# Altium schematic sheet Sheet1.SchDoc
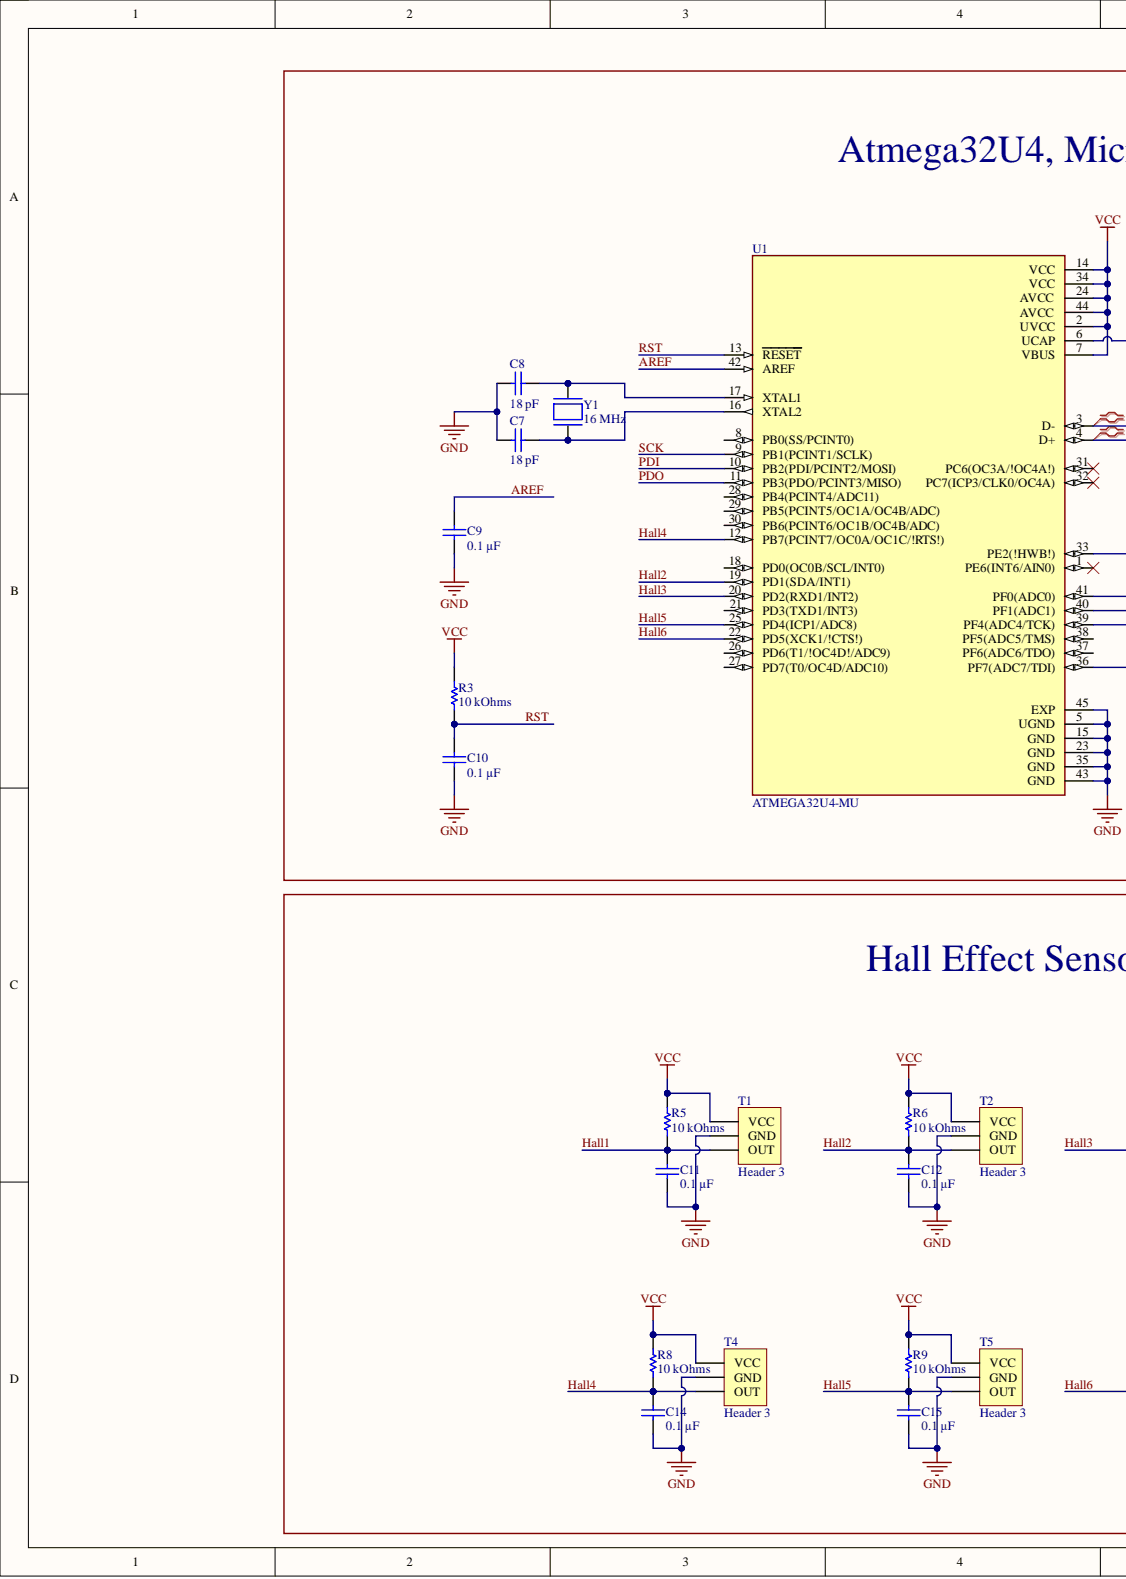
[diagram p0: Sheet1.SchDoc, left]
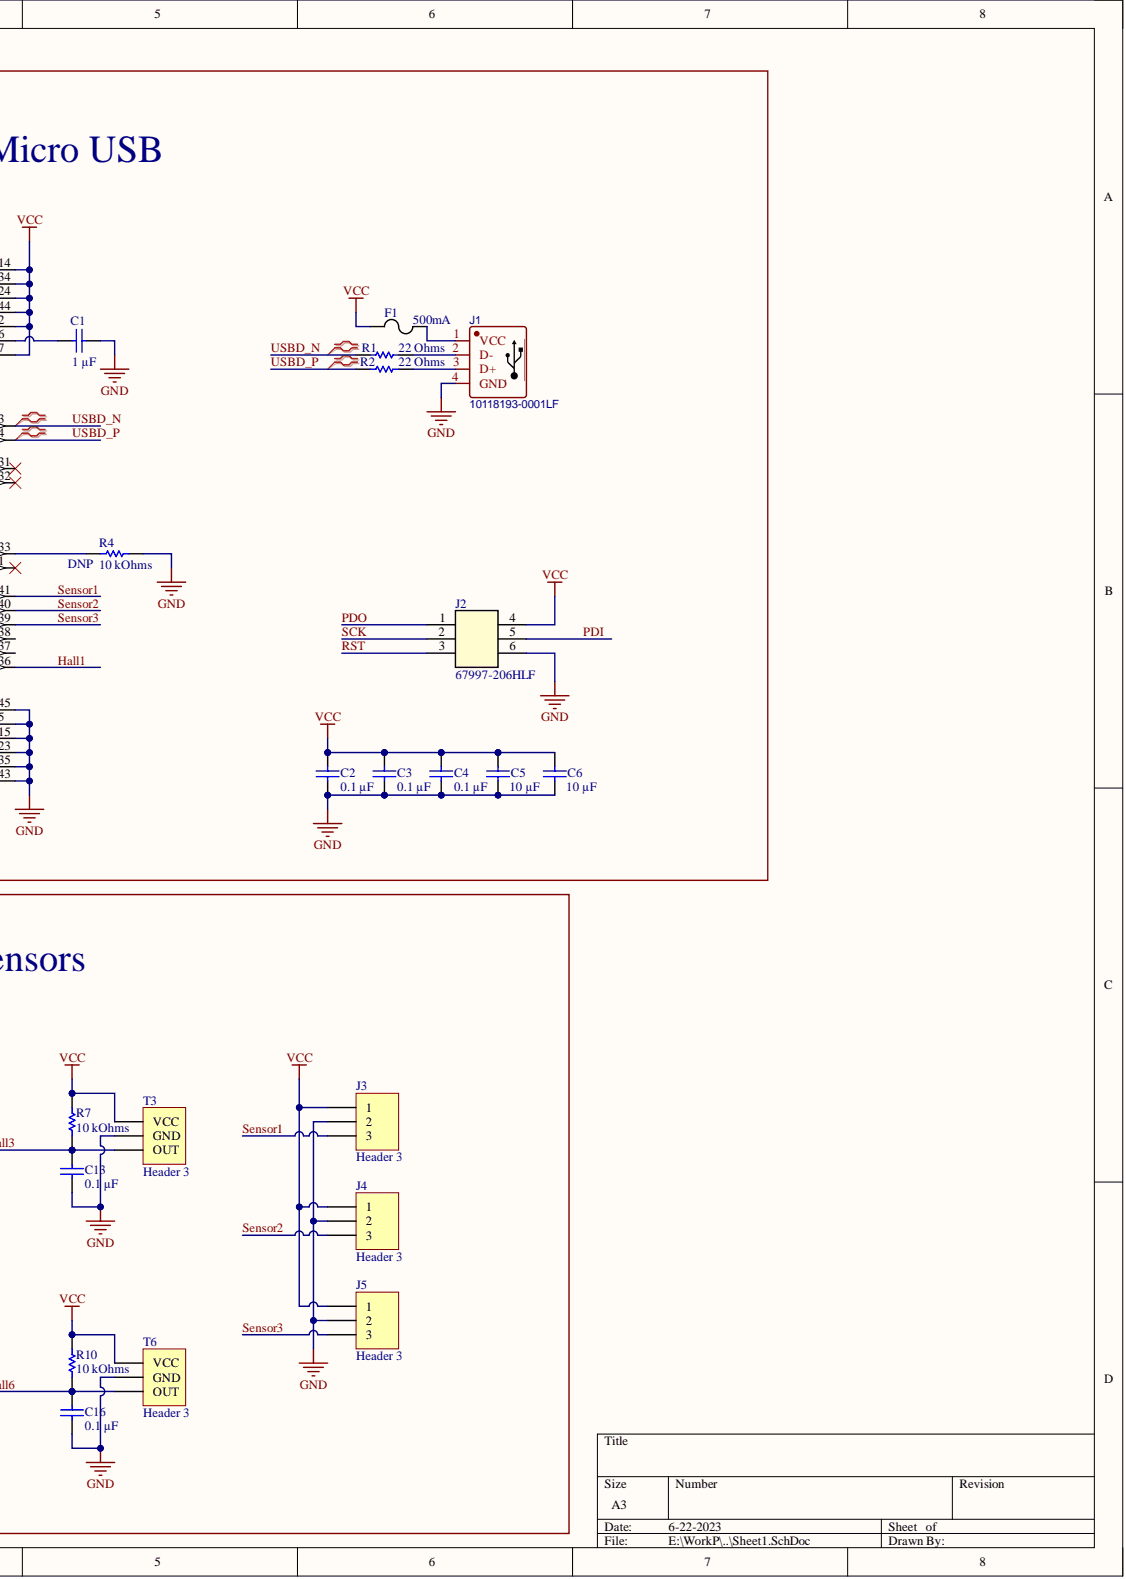
[diagram p1: Sheet1.SchDoc, right]

## Parts
C1  value 1 µF  [C0603]  (hidden comment: Cap)
C2  value 0.1 µF  [C0603]  (hidden comment: Cap)
C3  value 0.1 µF  [C0603]  (hidden comment: Cap)
C4  value 0.1 µF  [C0603]  (hidden comment: Cap)
C5  value 10 µF  [C0603]  (hidden comment: Cap)
C6  value 10 µF  [C0603]  (hidden comment: Cap)
C7  value 18 pF  [C0603]  (hidden comment: Cap)
C8  value 18 pF  [C0603]  (hidden comment: Cap)
C9  value 0.1 µF  [C0603]  (hidden comment: Cap)
C10  value 0.1 µF  [C0603]  (hidden comment: Cap)
C11  value 0.1 µF  [C0603]  (hidden comment: Cap)
C12  value 0.1 µF  [C0603]  (hidden comment: Cap)
C13  value 0.1 µF  [C0603]  (hidden comment: Cap)
C14  value 0.1 µF  [C0603]  (hidden comment: Cap)
C15  value 0.1 µF  [C0603]  (hidden comment: Cap)
C16  value 0.1 µF  [C0603]  (hidden comment: Cap)
F1  value 500mA  [FUSE_MF-FSMF050X_BRN]  (hidden comment: MF-FSMF050X-2)
J1  10118193-0001LF  [CONN-TH_S4B-ZR-LF-SN]
J2  67997-206HLF  [6Pins_AMP]
J3  Header 3  [HDR1X3]
J4  Header 3  [HDR1X3]
J5  Header 3  [HDR1X3]
R1  value 22 Ohms  [R0603]  (hidden comment: Res1)
R2  value 22 Ohms  [R0603]  (hidden comment: Res1)
R3  value 10 kOhms  [R0603]  (hidden comment: Res1)
R4  value 10 kOhms  Populate: DNP  [R0603]  (hidden comment: Res1)
R5  value 10 kOhms  [R0603]  (hidden comment: Res1)
R6  value 10 kOhms  [R0603]  (hidden comment: Res1)
R7  value 10 kOhms  [R0603]  (hidden comment: Res1)
R8  value 10 kOhms  [R0603]  (hidden comment: Res1)
R9  value 10 kOhms  [R0603]  (hidden comment: Res1)
R10  value 10 kOhms  [R0603]  (hidden comment: Res1)
T1  Header 3  [3144_Hall]
T2  Header 3  [3144_Hall]
T3  Header 3  [3144_Hall]
T4  Header 3  [3144_Hall]
T5  Header 3  [3144_Hall]
T6  Header 3  [3144_Hall]
U1  ATMEGA32U4-MU  [QFN50P700X700X100-45N]
Y1  value 16 MHz  [XTAL_NX5032GA-16.000000MHZ-LN-CD-1]  (hidden comment: NX5032GA-16.000000MHZ-LN-CD-1)

## Nets
GND: C1.2 C2.1 C3.1 C4.1 C5.1 C6.1 C7.2 C8.2 C9.1 C10.1 C11.1 C12.1 C13.1 C14.1 C15.1 C16.1 J1.4(GND) J2.6 J3.2 J4.2 J5.2 R4.1 T1.2 T2.2 T3.2 T4.2 T5.2 T6.2 U1.5(UGND) U1.15(GND) U1.23(GND) U1.35(GND) U1.43(GND) U1.45(EXP)
VCC: C2.2 C3.2 C4.2 C5.2 C6.2 F1.1 J2.4 J3.1 J4.1 J5.1 R3.2 R5.2 R6.2 R7.2 R8.2 R9.2 R10.2 T1.1 T2.1 T3.1 T4.1 T5.1 T6.1 U1.2(UVCC) U1.7(VBUS) U1.14(VCC) U1.24(AVCC) U1.34(VCC) U1.44(AVCC)
AREF: C9.2 U1.42(AREF)
Hall1: C11.2 R5.1 T1.3 U1.36(PF7(ADC7/TDI))
Hall2: C12.2 R6.1 T2.3 U1.19(PD1(SDA/INT1))
Hall3: C13.2 R7.1 T3.3 U1.20(PD2(RXD1/INT2))
Hall4: C14.2 R8.1 T4.3 U1.12(PB7(PCINT7/OC0A/OC1C/!RTS!))
Hall5: C15.2 R9.1 T5.3 U1.25(PD4(ICP1/ADC8))
Hall6: C16.2 R10.1 T6.3 U1.22(PD5(XCK1/!CTS!))
PDI: J2.5 U1.10(PB2(PDI/PCINT2/MOSI))
PDO: J2.1 U1.11(PB3(PDO/PCINT3/MISO))
RST: C10.2 J2.3 R3.1 U1.13(RESET)
SCK: J2.2 U1.9(PB1(PCINT1/SCLK))
Sensor1: J3.3 U1.41(PF0(ADC0))
Sensor2: J4.3 U1.40(PF1(ADC1))
Sensor3: J5.3 U1.39(PF4(ADC4/TCK))
USBD_N: R1.1 U1.3(D-)
USBD_P: R2.1 U1.4(D+)
NetC1_1: C1.1 U1.6(UCAP)
NetC7_1: C7.1 U1.16(XTAL2) Y1.1
NetC8_1: C8.1 U1.17(XTAL1) Y1.2
NetF1_2: F1.2 J1.1(VCC)
NetJ1_2: J1.2(D-) R1.2
NetJ1_3: J1.3(D+) R2.2
NetR4_2: R4.2 U1.33(PE2(!HWB!))

Not connected: U1 1(PE6(INT6/AIN0)) 8(PB0(SS/PCINT0)) 18(PD0(OC0B/SCL/INT0)) 21(PD3(TXD1/INT3)) 26(PD6(T1/!OC4D!/ADC9)) 27(PD7(T0/OC4D/ADC10)) 28(PB4(PCINT4/ADC11)) 29(PB5(PCINT5/OC1A/OC4B/ADC)) 30(PB6(PCINT6/OC1B/OC4B/ADC)) 31(PC6(OC3A/!OC4A!)) 32(PC7(ICP3/CLK0/OC4A)) 37(PF6(ADC6/TDO)) 38(PF5(ADC5/TMS))

## Library data of the parts
C1 = Cap: 25V 1uF X5R ±10% 0603 Multilayer Ceramic Capacitors MLCC - SMD/SMT ROHS; Manufacturer: YAGEO; Mfr. Part #: CC0603KRX5R8BB105; Component Kind: Standard; LCSC Part #: C14664; Library Name: Miscellaneous Devices.IntLib; Package: 0603; Signal Integrity: Cap; Simulation: CAP
C2 = Cap: CAP CER 0.1UF 25V X7R 0603; Manufacturer: CCTC; Mfr. Part #: TCC0603X7R104K500CT; Value: 0.1 µF; Component Kind: Standard; LCSC Part #: C282519; Library Name: Miscellaneous Devices.IntLib; Package: 0603; Signal Integrity: Cap; Simulation: CAP
C3 C4 C9-C16 = Cap: CAP CER 0.1UF 25V X7R 0603; Manufacturer: CCTC; Mfr. Part #: TCC0603X7R104K500CT; Component Kind: Standard; LCSC Part #: C282519; Library Name: Miscellaneous Devices.IntLib; Package: 0603; Signal Integrity: Cap; Simulation: CAP
C5 C6 = Cap: 10V 10uF X5R ±10% 0603 Multilayer Ceramic Capacitors MLCC - SMD/SMT ROHS; Manufacturer: CCTC; Mfr. Part #: TCC0603X5R106K100CT; Component Kind: Standard; LCSC Part #: C380314; Library Name: Miscellaneous Devices.IntLib; Package: 0603; Signal Integrity: Cap; Simulation: CAP
C7 C8 = Cap: 50V 18pF NP0 ±1% 0603 Multilayer Ceramic Capacitors MLCC - SMD/SMT ROHS; Manufacturer: YAGEO; Mfr. Part #: CC0603FRNPO9BN180; Component Kind: Standard; LCSC Part #: C527046; Library Name: Miscellaneous Devices.IntLib; Package: 0603; Signal Integrity: Cap; Simulation: CAP
F1 = MF-FSMF050X-2: PTC RESET FUSE 6V 500MA 0603; Manufacturer: Bourns Electronics; Component Kind: Standard; LCSC Part #: C210357; Library Name: Schlib1.SchLib; Mfr. Part #: MF-FSMF050X-2; Package: 0603
J1 = S4B-ZR (LF)(SN): 1x4P ZH 1 1.5mm 4 Brass Push-Pull,P=1.5mm Wire To Board / Wire To Wire Connector ROHS; Manufacturer: JST; BOM_Supplier: LCSC; Component Kind: Standard; LCSC Part #: C157924; Library Name: Schlib1.SchLib; Mfr. Part #: S4B-ZR(LF)(SN); Package: 4 POS
J2 = 67997-206HLF: CONN HEADER VERT 6POS 2.54MM; Manufacturer: BOOMELE(Boom Precision Elec); Mfr. Part #: C65114; Component Kind: Standard; LCSC Part #: C65114; Library Name: Schlib1.SchLib; Package: 6POS 2.54MM; Value: 6 pos
J3-J5 = Header 3: Header, 3-Pin; Component Kind: Standard; Library Name: Miscellaneous Connectors.IntLib; Signal Integrity: Connector
R1 R2 = Res1: RC0603FR-0722RL; Manufacturer: YAGEO; Component Kind: Standard; LCSC Part #: C107701; Library Name: Miscellaneous Devices.IntLib; Mfr. Part #: RC0603FR-0722RL; Package: 0603; Signal Integrity: Res; Simulation: RESISTOR
R3 R4 R10 = Res1: RES 10K OHM 1% 1/10W 0603; Manufacturer: UNI-ROYAL(Uniroyal Elec); Mfr. Part #: 0603WAF1002T5E; Component Kind: Standard; LCSC Part #: C25804; Library Name: Miscellaneous Devices.IntLib; Package: 0603; Signal Integrity: Res; Simulation: RESISTOR
R5-R9 = Res1: RES 10K OHM 1% 1/10W 0603; Manufacturer: UNI-ROYAL(Uniroyal Elec); Mfr. Part #: 0603WAF1002T5E; Value: 10 kOhms; Component Kind: Standard; LCSC Part #: C25804; Library Name: Miscellaneous Devices.IntLib; Package: 0603; Signal Integrity: Res; Simulation: RESISTOR
T1-T6 = Header 3: Digital Output Hall-effect sensor; Manufacturer: MicroSystems, INC; Manufacturer Product Number: 3144UA; Value: TINYTRONICS; Component Kind: Standard; Library Name: Miscellaneous Connectors.IntLib; Package: THT; Signal Integrity: Connector
U1 = ATMEGA32U4-MU: IC MCU 8BIT 32KB FLASH 44VQFN; Manufacturer: Microchip; Component Kind: Standard; LCSC Part #: C112161; Library Name: Schlib1.SchLib; Mfr. Part #: ATMEGA32U4-MU; Package: 44-VFQFN Exposed Pad
Y1 = NX5032GA-16.000000MHZ-LN-CD-1: CRYSTAL 16.0000MHZ 8PF SMD; Manufacturer: TAE(Zhejiang Abel Elec); Mfr. Part #: TAXM16M2QLFCDT1T; Component Kind: Standard; LCSC Part #: C122519; Library Name: Schlib1.SchLib; Package: 2-SMD, No Lead
